# Altium schematic sheet Test Board.SchDoc
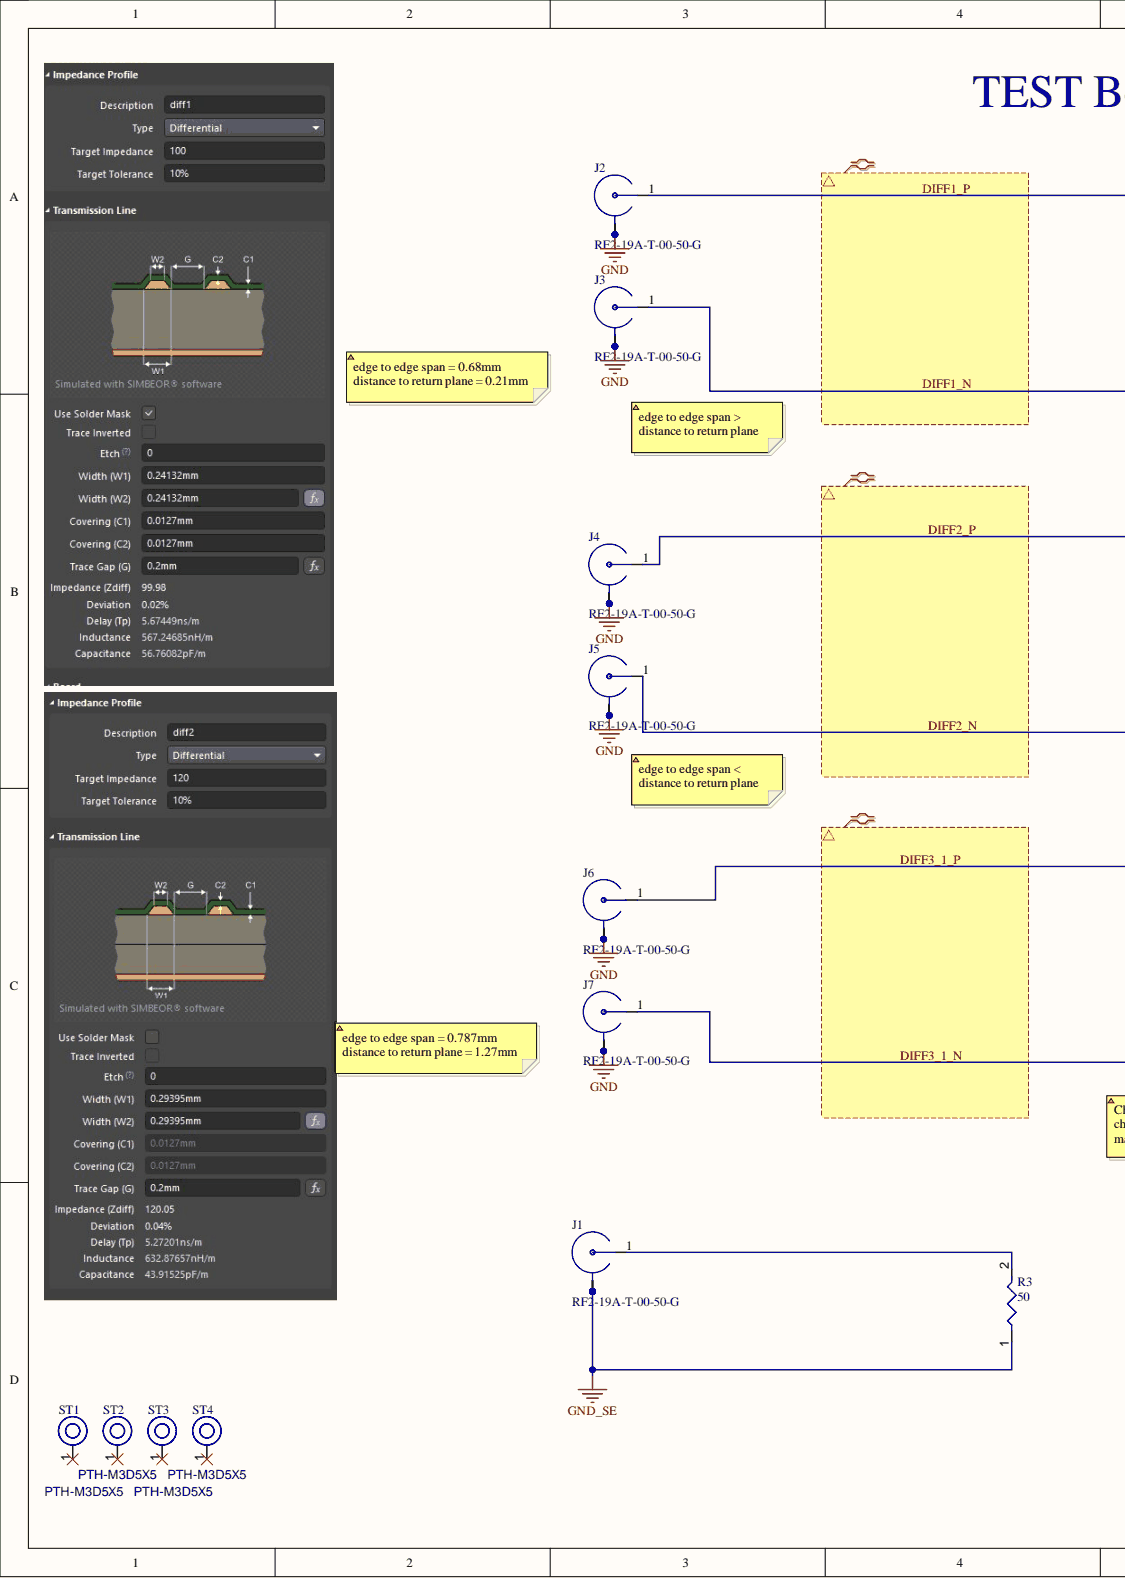
[diagram p0: Test Board.SchDoc, left]
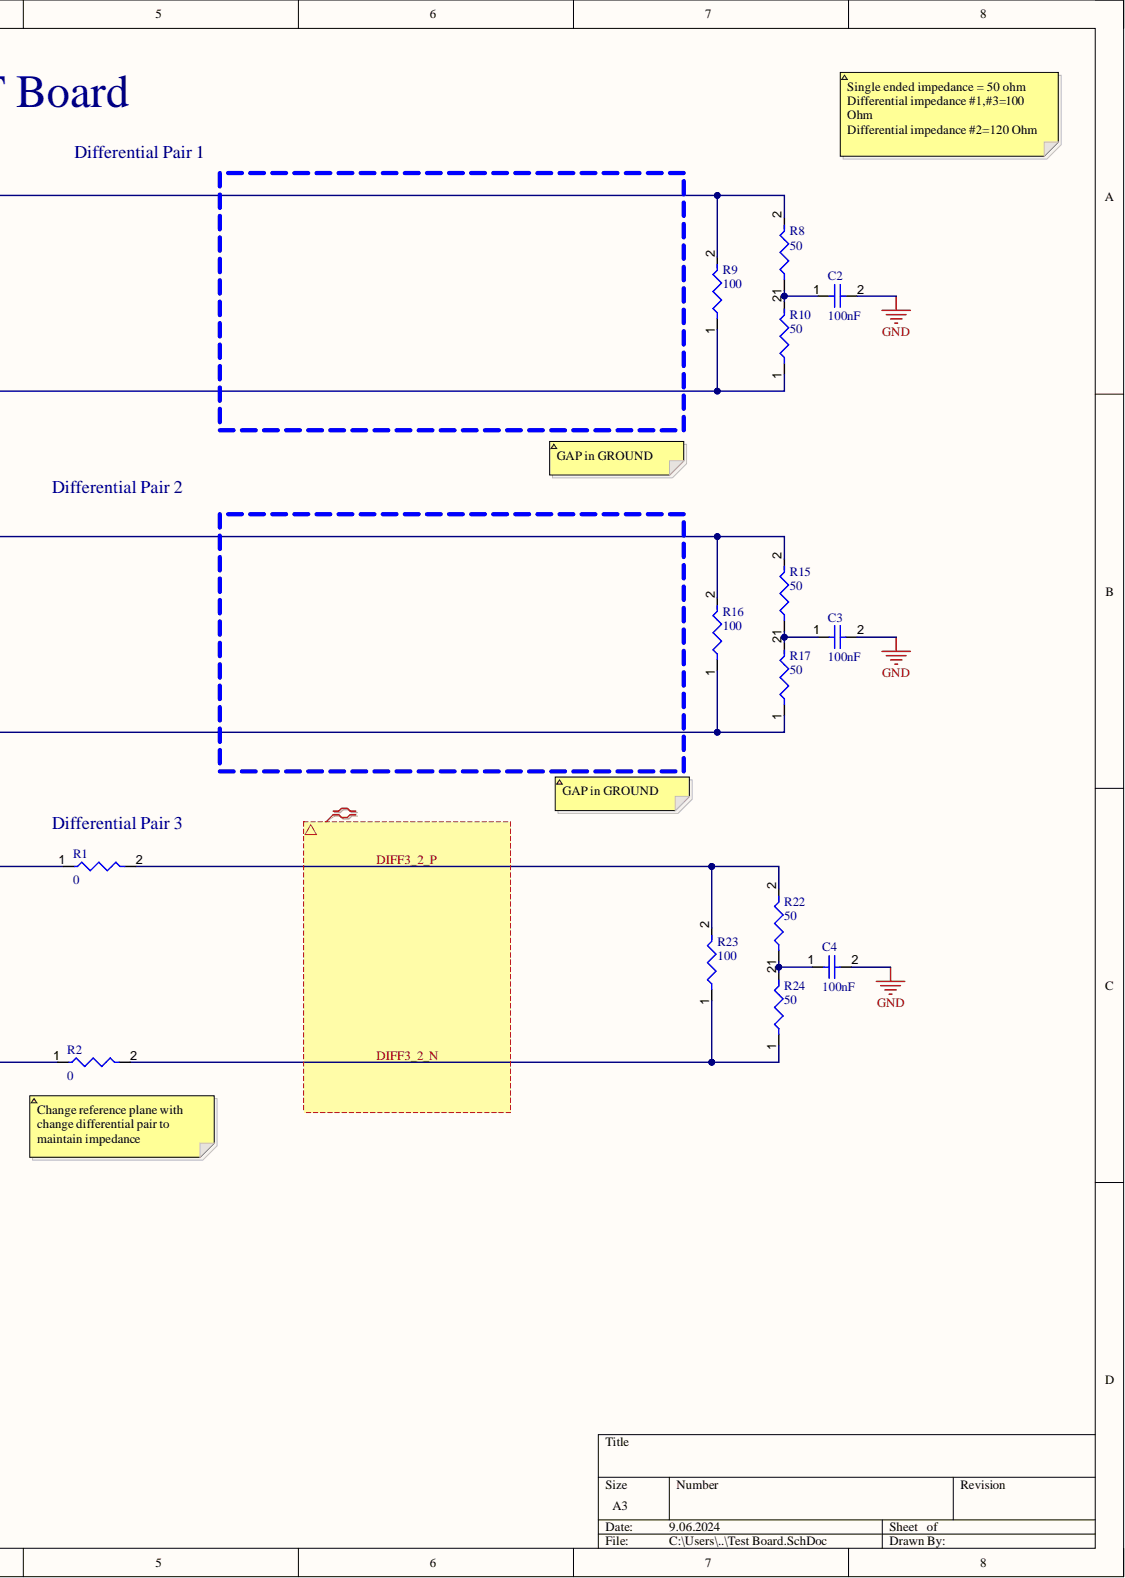
[diagram p1: Test Board.SchDoc, right]

## Parts
C2  value 100nF  [CAPC1608X90X40NL10T20]  (hidden comment: Capacitor 100 nF +/-10% 50 V 0603)
C3  value 100nF  [CAPC1608X90X40NL10T20]  (hidden comment: Capacitor 100 nF +/-10% 50 V 0603)
C4  value 100nF  [CAPC1608X90X40NL10T20]  (hidden comment: Capacitor 100 nF +/-10% 50 V 0603)
J1  RF2-19A-T-00-50-G  [RF2-19A-T-00-50-G-Footprint-1]
J2  RF2-19A-T-00-50-G  [RF2-19A-T-00-50-G-Footprint-1]
J3  RF2-19A-T-00-50-G  [RF2-19A-T-00-50-G-Footprint-1]
J4  RF2-19A-T-00-50-G  [RF2-19A-T-00-50-G-Footprint-1]
J5  RF2-19A-T-00-50-G  [RF2-19A-T-00-50-G-Footprint-1]
J6  RF2-19A-T-00-50-G  [RF2-19A-T-00-50-G-Footprint-1]
J7  RF2-19A-T-00-50-G  [RF2-19A-T-00-50-G-Footprint-1]
R1  value 0  [RESC0603(1608)_L]  (hidden comment: 0R3J)
R2  value 0  [RESC0603(1608)_L]  (hidden comment: 0R3J)
R3  value 50  [RESC1608X06N]  (hidden comment: CRCW060350R0FKTA)
R8  value 50  [RESC1608X06N]  (hidden comment: CRCW060350R0FKTA)
R9  value 100  [RESC1608X06N]  (hidden comment: CRCW0603100RFKEAHP)
R10  value 50  [RESC1608X06N]  (hidden comment: CRCW060350R0FKTA)
R15  value 50  [RESC1608X06N]  (hidden comment: CRCW060350R0FKTA)
R16  value 100  [RESC1608X06N]  (hidden comment: CRCW0603100RFKEAHP)
R17  value 50  [RESC1608X06N]  (hidden comment: CRCW060350R0FKTA)
R22  value 50  [RESC1608X06N]  (hidden comment: CRCW060350R0FKTA)
R23  value 100  [RESC1608X06N]  (hidden comment: CRCW0603100RFKEAHP)
R24  value 50  [RESC1608X06N]  (hidden comment: CRCW060350R0FKTA)
ST1  PTH-M3D5X5  [PTH-M3D5X5-Footprint-1]
ST2  PTH-M3D5X5  [PTH-M3D5X5-Footprint-1]
ST3  PTH-M3D5X5  [PTH-M3D5X5-Footprint-1]
ST4  PTH-M3D5X5  [PTH-M3D5X5-Footprint-1]

## Nets
GND: C2.2 C3.2 C4.2 J2.2 J2.3 J2.4 J2.5 J3.2 J3.3 J3.4 J3.5 J4.2 J4.3 J4.4 J4.5 J5.2 J5.3 J5.4 J5.5 J6.2 J6.3 J6.4 J6.5 J7.2 J7.3 J7.4 J7.5
GND_SE: J1.2 J1.3 J1.4 J1.5 R3.1
DIFF1_N: J3.1 R9.1 R10.1
DIFF1_P: J2.1 R8.2 R9.2
DIFF2_N: J5.1 R16.1 R17.1
DIFF2_P: J4.1 R15.2 R16.2
DIFF3_1_N: J7.1 R2.1
DIFF3_1_P: J6.1 R1.1
DIFF3_2_N: R2.2 R23.1 R24.1
DIFF3_2_P: R1.2 R22.2 R23.2
NetC2_1: C2.1 R8.1 R10.2
NetC3_1: C3.1 R15.1 R17.2
NetC4_1: C4.1 R22.1 R24.2
NetJ1_1: J1.1 R3.2

Not connected: ST1 1; ST2 1; ST3 1; ST4 1

## Library data of the parts
C2-C4 = CMP-001-00017-8: Chip Capacitor, 100 nF, +/- 10%, 50 V, 0603 (1608 Metric); Capacitor Type: Ceramic; Voltage Rating: 50V; Case/Package: 0603; Component Kind: Standard; Max Operating Temperature: 125°C; Min Operating Temperature: -55°C; Mounting Technology: SM; Pins: 2; RoHS Compliant: True; Tolerance: 10%; Library Name: Warsaw University of Technology
J1-J7 = CMP-002-00081-1: SMA DC-18GHZ;  50OHMS; gold plated; PTFE; Connector Type: THT; Gender: FEMALE; Voltage Rating: 335V; Case/Package: SMA; Component Kind: Standard; Max Operating Temperature: 165°C; Min Operating Temperature: -65°C; Pins: 5; RoHS Compliant: ROHS; Library Name: Warsaw University of Technology
R1 R2 = CMP-009-00063-13: Jumper 0603 (1608 Metric); Power: 125mW; Case/Package: 0603; Component Kind: Standard; Mounting Technology: SMT; Pins: 2; Library Name: Warsaw University of Technology
R3 R8 R10 R15 R17 R22 R24 = CMP-009-00225-2: Thick Film Resistors - SMD 1/10watt 50ohms 1% Non Standard; Package Height: 0.45mm; Package Length: 1.6mm; Package Width: 0.8mm; Power: 100mW; Rated Temperature: 70°C; Temperature Coefficient: 100ppm/°C; Voltage Rating: 75V; Case/Package: 0603; Component Kind: Standard; Construction: Chip; Max Operating Temperature: 155°C; Min Operating Temperature: -55°C; Mounting Technology: Surface Mount; Package Style: SMTMeter; Packaging: Tape and Reel; Pins: 2; Reach SVHC Compliant: No; Resistor Type: FIXED RESISTOR; RoHS Compliant: No; Technology: THIN FILM; Termination: Wraparound; Tolerance: 1%; Working Voltage: 75V; Library Name: Warsaw University of Technology
R9 R16 R23 = CMP-009-00188-3: Fixed Resistor, Metal Glaze/thick Film, 0.33W, 100ohm, 75V, 1% +/-Tol, 100ppm/Cel, Surface Mount, 0603; Power: 250mW; Case/Package: 0603; Component Kind: Standard; Max Operating Temperature: 155°C; Min Operating Temperature: -55°C; Mounting Technology: Surface Mount; Pins: 2; RoHS Compliant: Yes; Tolerance: 1%; Library Name: Warsaw University of Technology
ST1-ST4 = CMP-00028-00010-1: M3 PTH (3mm), 5mm pad; Component Kind: Standard; Pins: 1; Library Name: Warsaw University of Technology
